# Altium schematic sheet 03 FPGA_POWER.SchDoc
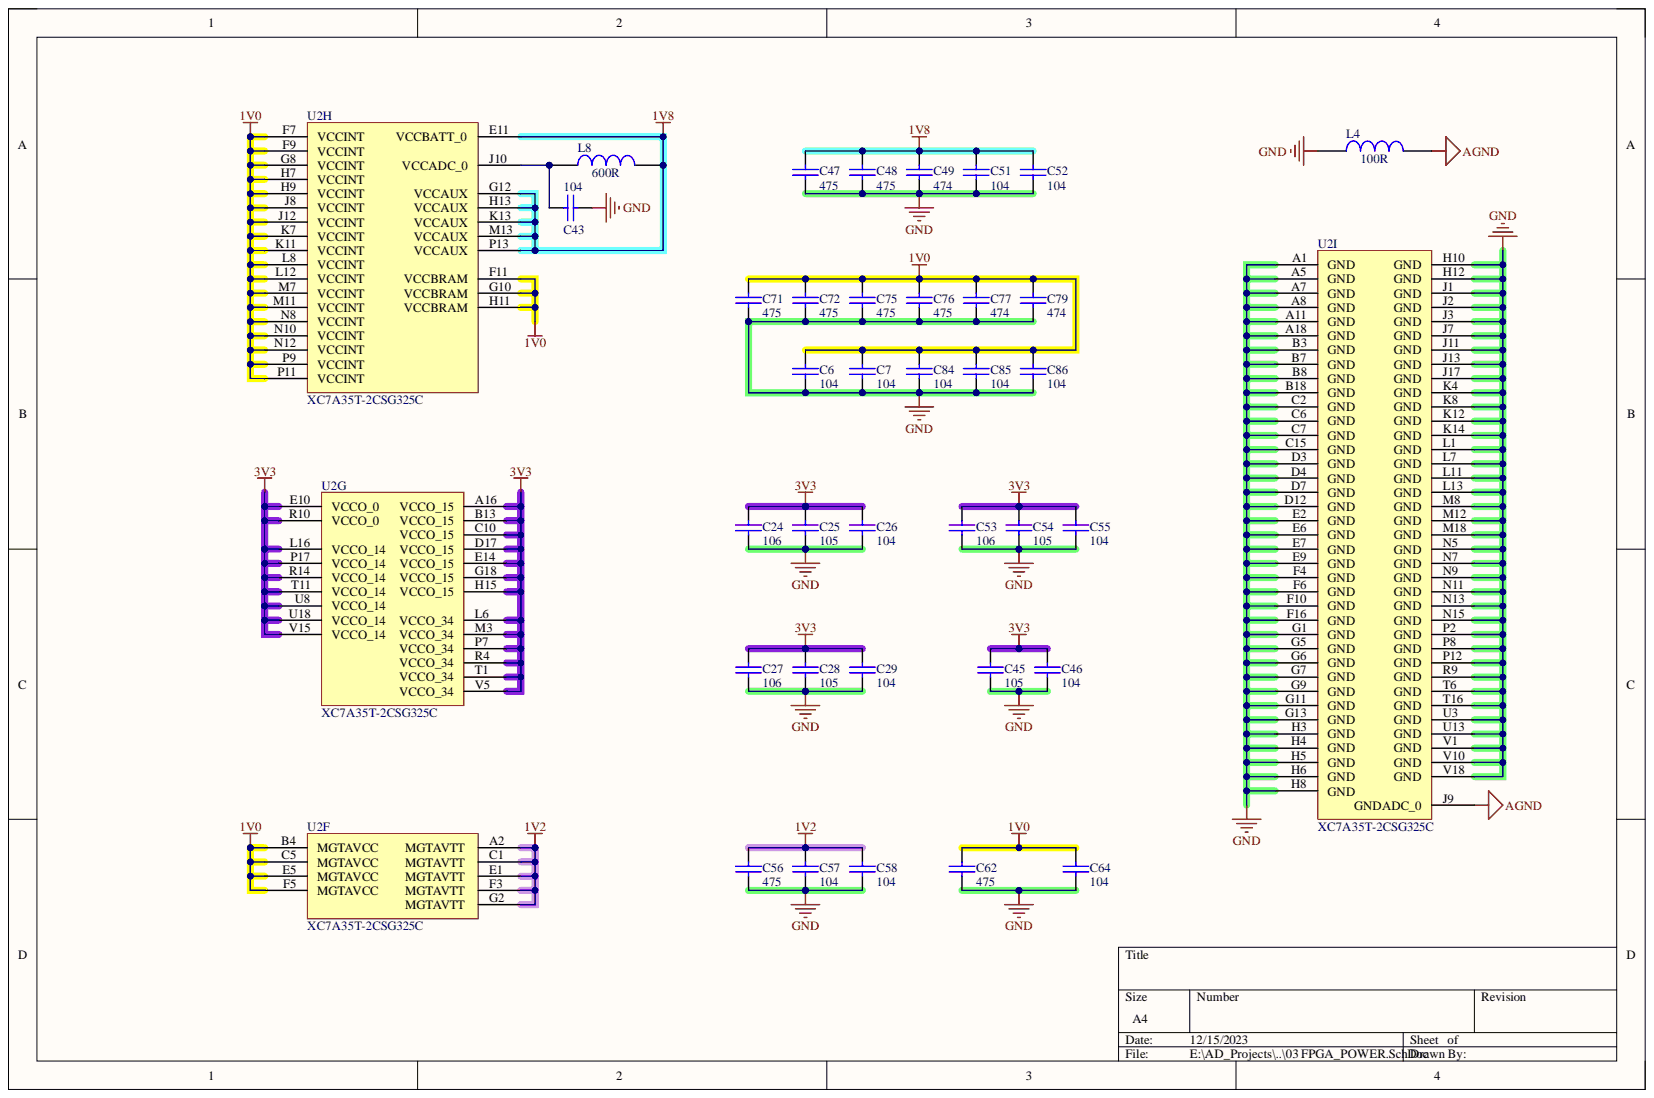
[diagram p0: 03 FPGA_POWER.SchDoc]

## Parts
C6  104  [0402C]
C7  104  [0402C]
C24  106  [0402C]
C25  105  [0402C]
C26  104  [0402C]
C27  106  [0402C]
C28  105  [0402C]
C29  104  [0402C]
C43  104  [0402C]
C45  105  [0402C]
C46  104  [0402C]
C47  475  [0402C]
C48  475  [0402C]
C49  474  [0402C]
C51  104  [0402C]
C52  104  [0402C]
C53  106  [0402C]
C54  105  [0402C]
C55  104  [0402C]
C56  475  [0402C]
C57  104  [0402C]
C58  104  [0402C]
C62  475  [0402C]
C64  104  [0402C]
C71  475  [0402C]
C72  475  [0402C]
C75  475  [0402C]
C76  475  [0402C]
C77  474  [0402C]
C79  474  [0402C]
C84  104  [0402C]
C85  104  [0402C]
C86  104  [0402C]
L4  100R  [0603L]
L8  600R  [0603L]
U2  XC7A35T-2CSG325C  [CSG325]  (parts F,G,H,I)

## Nets
1V0: C6.2 C7.2 C62.2 C64.2 C71.2 C72.2 C75.2 C76.2 C77.2 C79.2 C84.2 C85.2 C86.2 U2.B4(MGTAVCC) U2.C5(MGTAVCC) U2.E5(MGTAVCC) U2.F5(MGTAVCC) U2.F7(VCCINT) U2.F9(VCCINT) U2.F11(VCCBRAM) U2.G8(VCCINT) U2.G10(VCCBRAM) U2.H7(VCCINT) U2.H9(VCCINT) U2.H11(VCCBRAM) U2.J8(VCCINT) U2.J12(VCCINT) U2.K7(VCCINT) U2.K11(VCCINT) U2.L8(VCCINT) U2.L12(VCCINT) U2.M7(VCCINT) U2.M11(VCCINT) U2.N8(VCCINT) U2.N10(VCCINT) U2.N12(VCCINT) U2.P9(VCCINT) U2.P11(VCCINT)
1V2: C56.2 C57.2 C58.2 U2.A2(MGTAVTT) U2.C1(MGTAVTT) U2.E1(MGTAVTT) U2.F3(MGTAVTT) U2.G2(MGTAVTT)
1V8: C47.2 C48.2 C49.2 C51.2 C52.2 L8.2 U2.E11(VCCBATT_0) U2.G12(VCCAUX) U2.H13(VCCAUX) U2.K13(VCCAUX) U2.M13(VCCAUX) U2.P13(VCCAUX)
3V3: C24.2 C25.2 C26.2 C27.2 C28.2 C29.2 C45.2 C46.2 C53.2 C54.2 C55.2 U2.A16(VCCO_15) U2.B13(VCCO_15) U2.C10(VCCO_15) U2.D17(VCCO_15) U2.E10(VCCO_0) U2.E14(VCCO_15) U2.G18(VCCO_15) U2.H15(VCCO_15) U2.L6(VCCO_34) U2.L16(VCCO_14) U2.M3(VCCO_34) U2.P7(VCCO_34) U2.P17(VCCO_14) U2.R4(VCCO_34) U2.R10(VCCO_0) U2.R14(VCCO_14) U2.T1(VCCO_34) U2.T11(VCCO_14) U2.U8(VCCO_14) U2.U18(VCCO_14) U2.V5(VCCO_34) U2.V15(VCCO_14)
AGND: L4.2 U2.J9(GNDADC_0)
GND: C6.1 C7.1 C24.1 C25.1 C26.1 C27.1 C28.1 C29.1 C43.2 C45.1 C46.1 C47.1 C48.1 C49.1 C51.1 C52.1 C53.1 C54.1 C55.1 C56.1 C57.1 C58.1 C62.1 C64.1 C71.1 C72.1 C75.1 C76.1 C77.1 C79.1 C84.1 C85.1 C86.1 L4.1 U2.A1(GND) U2.A5(GND) U2.A7(GND) U2.A8(GND) U2.A11(GND) U2.A18(GND) U2.B3(GND) U2.B7(GND) U2.B8(GND) U2.B18(GND) U2.C2(GND) U2.C6(GND) U2.C7(GND) U2.C15(GND) U2.D3(GND) U2.D4(GND) U2.D7(GND) U2.D12(GND) U2.E2(GND) U2.E6(GND) U2.E7(GND) U2.E9(GND) U2.F4(GND) U2.F6(GND) U2.F10(GND) U2.F16(GND) U2.G1(GND) U2.G5(GND) U2.G6(GND) U2.G7(GND) U2.G9(GND) U2.G11(GND) U2.G13(GND) U2.H3(GND) U2.H4(GND) U2.H5(GND) U2.H6(GND) U2.H8(GND) U2.H10(GND) U2.H12(GND) U2.J1(GND) U2.J2(GND) U2.J3(GND) U2.J7(GND) U2.J11(GND) U2.J13(GND) U2.J17(GND) U2.K4(GND) U2.K8(GND) U2.K12(GND) U2.K14(GND) U2.L1(GND) U2.L7(GND) U2.L11(GND) U2.L13(GND) U2.M8(GND) U2.M12(GND) U2.M18(GND) U2.N5(GND) U2.N7(GND) U2.N9(GND) U2.N11(GND) U2.N13(GND) U2.N15(GND) U2.P2(GND) U2.P8(GND) U2.P12(GND) U2.R9(GND) U2.T6(GND) U2.T16(GND) U2.U3(GND) U2.U13(GND) U2.V1(GND) U2.V10(GND) U2.V18(GND)
NetC43_1: C43.1 L8.1 U2.J10(VCCADC_0)

## Library data of the parts
C6 C7 C24-C29 C43 C45-C49 C51-C58 C62 C64 C71 C72 C75-C77 C79 C84-C86 = CAP: 无极性电容; Component Kind: Standard; Library Name: 电容.IntLib
L4 L8 = INDUCTOR: Component Kind: Standard; Description: INDUCTOR; Library Name: 电感.IntLib
U2 = XC7A35T-2CSG325C: Artix-7 FPGA, 150 User I/Os, 4 GTP, 324-Ball BGA, Speed Grade 2, Commercial Grade, Pb-Free; Manufacturer: Xilinx; Available User I/O: 150; Clock Mgmt Tiles (CMTs): 5; GTP: 4; Logic Cells: 33280; Speed Grades: 2; Total Block RAM: 1800 Kb; Component Kind: Standard; ComponentLink1Description: Manufacturer URL; ComponentLink1URL: http://www.xilinx.com/; ComponentLink2Description: Datasheet; ComponentLink2URL: http://www.xilinx.com/support/documentation/data_sheets/ds180_7Series_Overview.pdf; ComponentLink3Description: Package Specification; ComponentLink3URL: http://www.xilinx.com/support/documentation/user_guides/ug475_7Series_Pkg_Pinout.pdf; ComponentLink4Description: DC and Switching Characteristics; ComponentLink4URL: http://www.xilinx.com/support/documentation/data_sheets/ds181_Artix_7_Data_Sheet.pdf; ComponentLink5Description: Package File; ComponentLink5URL: http://www.xilinx.com/support/packagefiles/a7packages/xc7a200tsbg484pkg.txt; ComponentLink6Description: Packaging and Pinout; ComponentLink6URL: http://www.xilinx.com/support/documentation/user_guides/ug475_7Series_Pkg_Pinout.pdf; DatasheetVersion: v1.15; Library Name: Xilinx Artix-7.IntLib; Maximum Distributed RAM: 400 Kb; Mounting Technology: Surface Mount; NexusDeviceID: XC7A35T-2CSG325C; Operating Temperature Range: Commercial; PackageDescription: 324-Ball Wire-Bond Chip-Scale BGA, Body 15 x 15 mm, Pitch 0.8 mm; PackageReference: CSG-325; PackageVersion: Rev. 1.11; PartNumber: XC7A35T-2CSG325C
